# Altium schematic sheet D2A-Rev2b.SchDoc
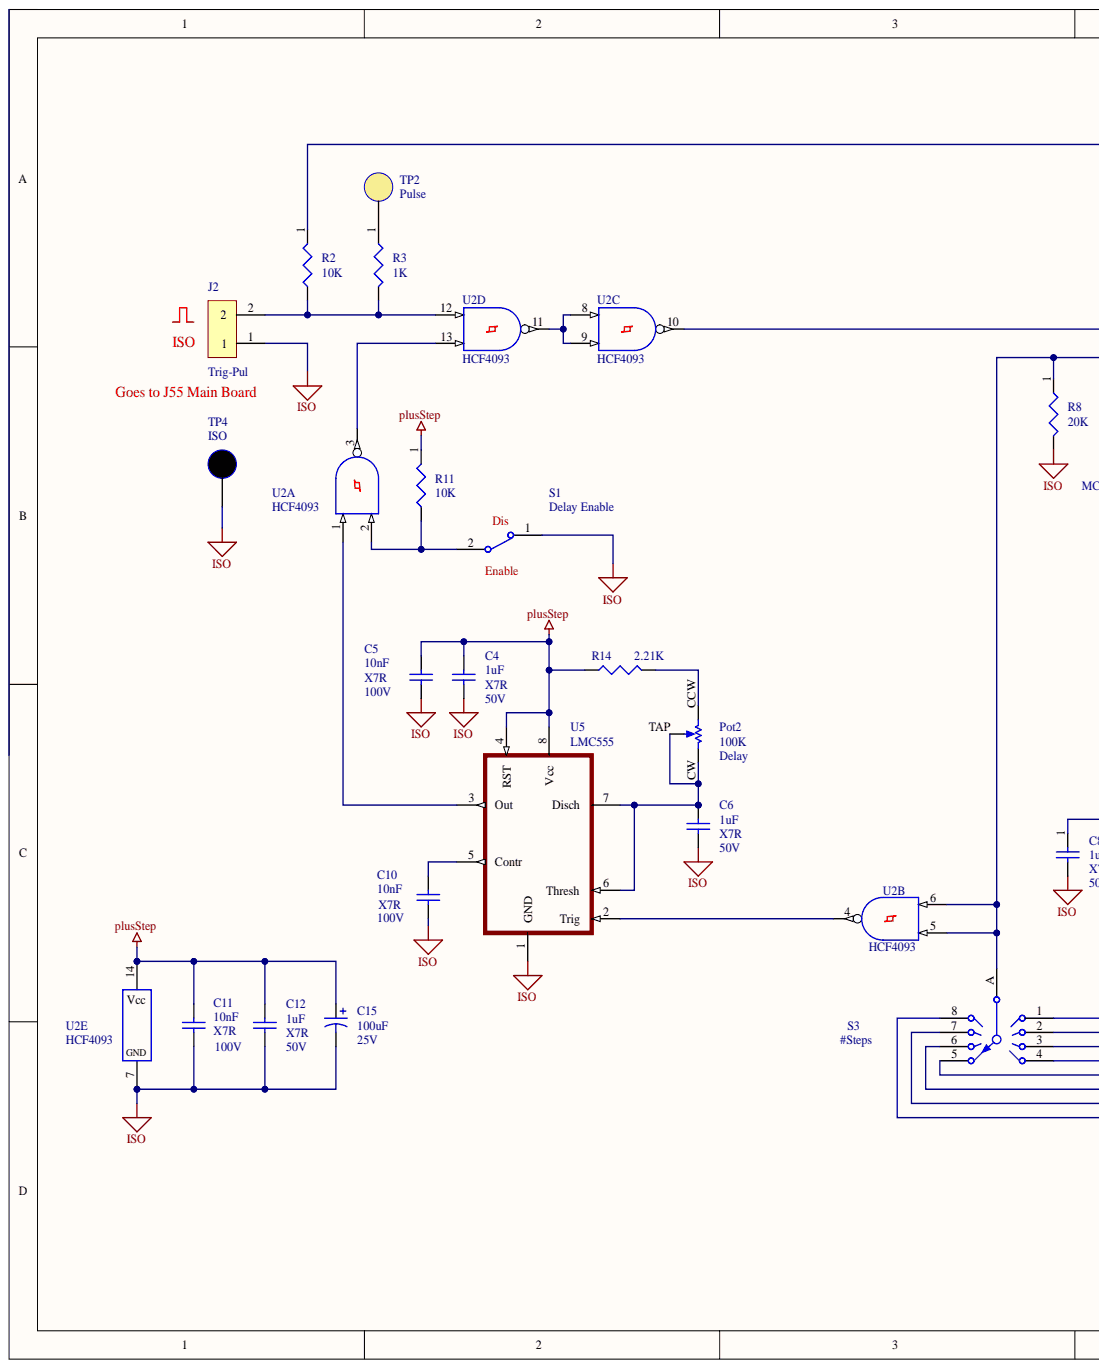
[diagram p0: D2A-Rev2b.SchDoc, left]
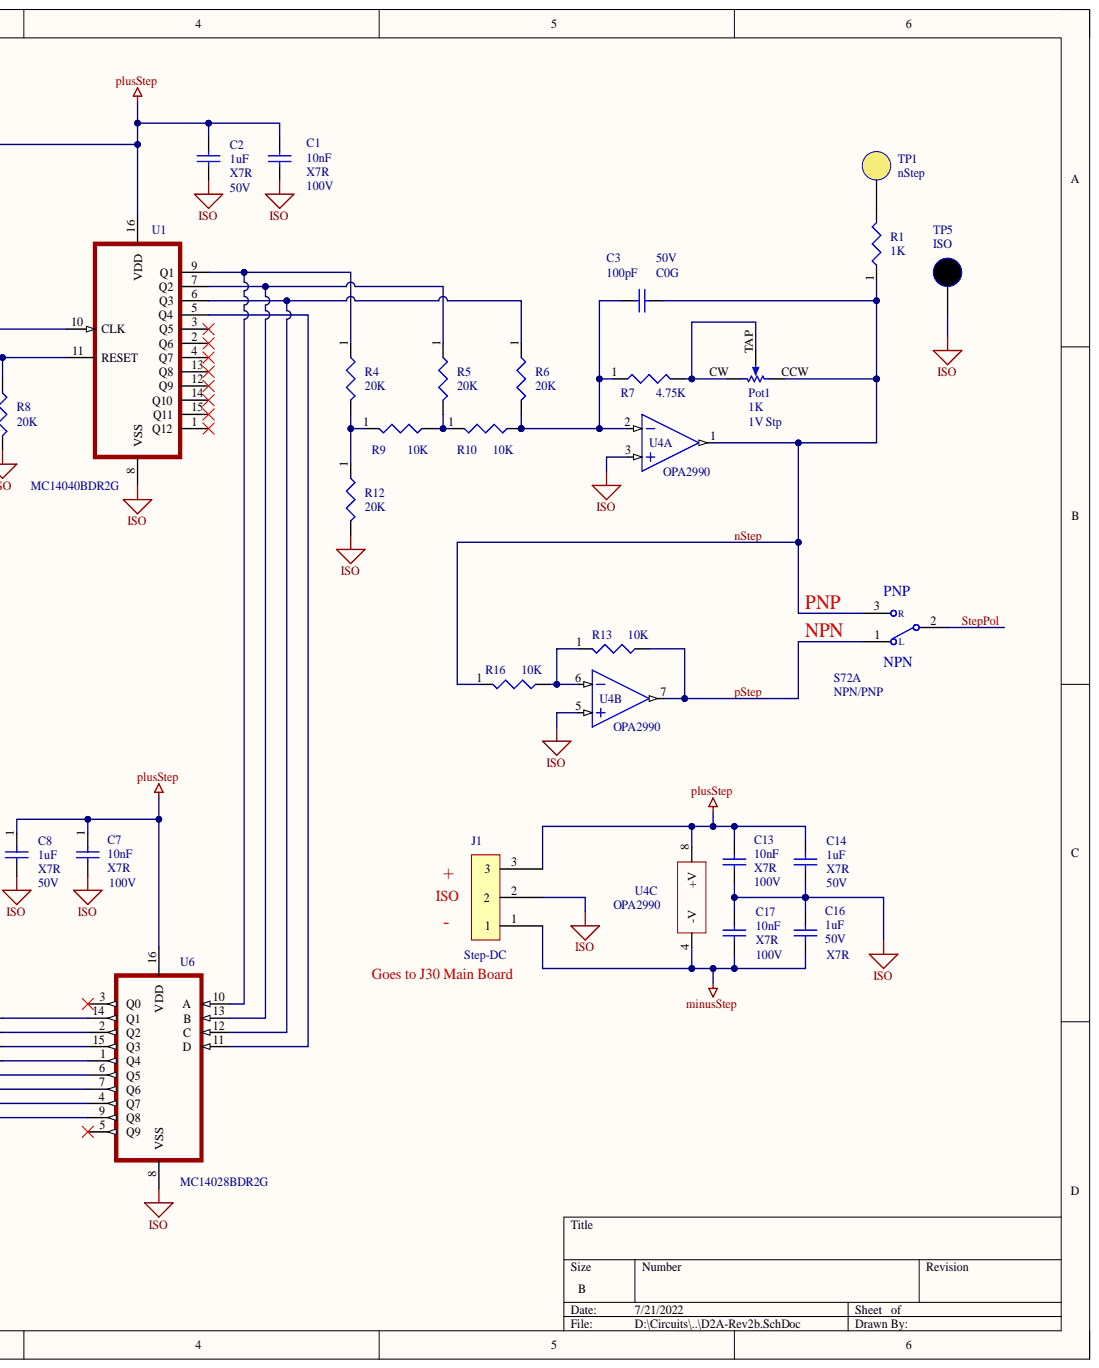
[diagram p1: D2A-Rev2b.SchDoc, right]

## Parts
C1  10nF  Dielectric: X7R  Voltage: 100V  [CAPC2012M]
C2  1uF  Dielectric: X7R  Voltage: 50V  [CAPC2012M]
C3  100pF  Dielectric: C0G  Voltage: 50V  [CAPC2012M]
C4  1uF  Dielectric: X7R  Voltage: 50V  [CAPC2012M]
C5  10nF  Dielectric: X7R  Voltage: 100V  [CAPC2012M]
C6  1uF  Dielectric: X7R  Voltage: 50V  [CAPC2012M]
C7  10nF  Dielectric: X7R  Voltage: 100V  [CAPC2012M]
C8  1uF  Dielectric: X7R  Voltage: 50V  [CAPC2012M]
C10  10nF  Dielectric: X7R  Voltage: 100V  [CAPC2012M]
C11  10nF  Dielectric: X7R  Voltage: 100V  [CAPC2012M]
C12  1uF  Dielectric: X7R  Voltage: 50V  [CAPC2012M]
C13  10nF  Dielectric: X7R  Voltage: 100V  [CAPC2012M]
C14  1uF  Dielectric: X7R  Voltage: 50V  [CAPC2012M]
C15  100uF  Voltage: 25V  [CAPPR2-5x11]
C16  1uF  Dielectric: X7R  Voltage: 50V  [CAPC2012M]
C17  10nF  Dielectric: X7R  Voltage: 100V  [CAPC2012M]
J1  Step-DC  [DTA-100-3]
J2  Trig-Pul  [DTA-100-2]
Pot1  1V Stp  value 1K  [7mmSq-CCW]
Pot2  Delay  value 100K  [Off-PCB-CCW]
R1  1K  [RESC2012M]
R2  10K  [RESC2012M]
R3  1K  [RESC2012M]
R4  20K  [RESC2012M]
R5  20K  [RESC2012M]
R6  20K  [RESC2012M]
R7  4.75K  [RESC2012M]
R8  20K  [RESC2012M]
R9  10K  [RESC2012M]
R10  10K  [RESC2012M]
R11  10K  [RESC2012M]
R12  20K  [RESC2012M]
R13  10K  [RESC2012M]
R14  2.21K  [RESC2012M]
R16  10K  [RESC2012M]
S1  Delay Enable  [2X1H-LS0.1-9mmHole]
S3  #Steps  [MRA112]
S72  NPN/PNP  [EG2425]  (part A)
TP1  nStep  [TP-.038]
TP2  Pulse  [TP-.038]
TP4  ISO  [TP-.038]
TP5  ISO  [TP-.038]
U1  MC14040BDR2G  [SOIC127P600X16-M]
U2  HCF4093  [SOIC127P600X14-M]  (parts A,B,C,D,E)
U4  OPA2990  [SOIC127P600X168_HS-8N]  (parts A,B,C)
U5  LMC555  [SOIC127P600X168_HS-8N]
U6  MC14028BDR2G  [SOIC127P600X16]

## Nets
ISO: C1.2 C2.2 C4.2 C5.2 C6.2 C7.2 C8.2 C10.2 C11.2 C12.2 C13.2 C14.2 C15.2 C16.2 C17.2 J1.2 J2.1 R8.2 R12.2 S1.1 TP4.1 TP5.1 U1.8(VSS) U2.7 U4.3 U4.5 U5.1(GND) U6.8(VSS)
minusStep: C16.1 C17.1 J1.1 U4.4(-V)
plusStep: C1.1 C2.1 C4.1 C5.1 C7.1 C8.1 C11.1 C12.1 C13.1 C14.1 C15.1 J1.3 R2.1 R11.1 R14.1 U1.16(VDD) U2.14 U4.8(+V) U5.4(RST) U5.8(Vcc) U6.16(VDD)
nStep: C3.2 Pot1.CCW R1.1 R16.1 S72.3(R) U4.1
pStep: R13.2 S72.1(L) U4.7
StepPol: S72.2
NetC3_1: C3.1 R6.2 R7.1 R10.2 U4.2
NetC6_1: C6.1 Pot2.CW Pot2.TAP U5.6(Thresh) U5.7(Disch)
NetC10_1: C10.1 U5.5(Contr)
NetJ2_2: J2.2 R2.2 R3.2 U2.12
NetPot1_CW: Pot1.CW Pot1.TAP R7.2
NetPot2_CCW: Pot2.CCW R14.2
NetR1_2: R1.2 TP1.1
NetR3_1: R3.1 TP2.1
NetR4_1: R4.1 U1.9(Q1) U6.10(A)
NetR4_2: R4.2 R9.1 R12.1
NetR5_1: R5.1 U1.7(Q2) U6.13(B)
NetR5_2: R5.2 R9.2 R10.1
NetR6_1: R6.1 U1.6(Q3) U6.12(C)
NetR8_1: R8.1 S3.A U1.11(RESET) U2.5 U2.6
NetR11_2: R11.2 S1.2 U2.2
NetR13_1: R13.1 R16.2 U4.6
NetS3_1: S3.1 U6.14(Q1)
NetS3_2: S3.2 U6.2(Q2)
NetS3_3: S3.3 U6.15(Q3)
NetS3_4: S3.4 U6.1(Q4)
NetS3_5: S3.5 U6.6(Q5)
NetS3_6: S3.6 U6.7(Q6)
NetS3_7: S3.7 U6.4(Q7)
NetS3_8: S3.8 U6.9(Q8)
NetU1_5: U1.5(Q4) U6.11(D)
NetU1_10: U1.10(CLK) U2.10
NetU2_1: U2.1 U5.3(Out)
NetU2_3: U2.3 U2.13
NetU2_4: U2.4 U5.2(Trig)
NetU2_8: U2.8 U2.9 U2.11

Not connected: U1 1(Q12) 2(Q6) 3(Q5) 4(Q7) 12(Q9) 13(Q8) 14(Q10) 15(Q11); U6 3(Q0) 5(Q9)

## Library data of the parts
C1 C5 C7 C10 C11 C13 C17 = Cap: CAP CER 10NF 100V X7R 0805; Manufacturer: Samsung; Manufacturer Part Number: CL21B103KCANNNC; Dielectric Material: Ceramic; Dissipation Factor: 2.5%; Tolerance: 10%; Capacitance: 10nF; Case Code (Imperial): 0805; Case Code (Metric): 2012; Case/Package: 0805; Component Kind: Standard; Construction: Flat; Contact Plating: Tin; Depth: 1.25mm; Height: 0.65mm; Length: 2mm; Library Name: Miscellaneous Devices.IntLib; Material: Ceramic; Max Operating Temperature: 125°C; Min Operating Temperature: -55°C; Mount: Surface Mount; Number of Pins: 2; Package Quantity: 1; Packaging: Tape and Reel; Radiation Hardening: No; REACH SVHC: No SVHC; RoHS Compliant: Yes; Series: CL; Signal Integrity: Cap; Simulation: CAP; Supplier: Digi-Key; Supplier Part Number: 1276-1249-6-ND; Termination: SMD/SMT; Thickness: 0.03inch; Value: 10nF; Voltage Rating: 100V; Voltage Rating (DC): 100V; Width: 0.049inch
C2 C4 C6 C8 C12 C14 C16 = Cap: CAP CER 1UF 50V X7R 0805; Manufacturer: Samsung; Manufacturer Part Number: CL21B105KBFNNNE; Dielectric Material: Ceramic; Tolerance: 10%; Capacitance: 1uF; Case Code (Imperial): 0805; Case Code (Metric): 2012; Case/Package: 0805; Component Kind: Standard; Construction: Flat; Depth: 1.25mm; Height: 1.25mm; Length: 2mm; Library Name: Miscellaneous Devices.IntLib; Max Operating Temperature: 125°C; Min Operating Temperature: -55°C; Mount: Surface Mount; Number of Pins: 2; Package Quantity: 1; Packaging: Tape and Reel; Radiation Hardening: No; REACH SVHC: No SVHC; RoHS Compliant: Yes; Series: CL; Signal Integrity: Cap; Simulation: CAP; Supplier: Digi-Key; Supplier Part Number: 1276-1029-6-ND; Termination: SMD/SMT; Thickness: 0.053inch; Value: 1uF; Voltage Rating: 50V; Voltage Rating (DC): 50V; Width: 0.049inch
C3 = Cap: CAP CER 100PF 50V COG 0805; Manufacturer: KEMET; Manufacturer Part Number: C0805C101J5GACTU; Dielectric Material: Ceramic; Dissipation Factor: 0.1%; Temperature Coefficient: 30ppm/°C; Tolerance: 5%; Capacitance: 100pF; Case Code (Imperial): 0805; Case Code (Metric): 2012; Case/Package: 0805; Component Kind: Standard; Construction: Flat; Contact Material: Tin; Contact Plating: Tin,Matte; Depth: 1.25mm; Height: 0.78mm; Lead Free: Lead Free; Lead Pitch: 0.75mm; Length: 2mm; Library Name: Miscellaneous Devices.IntLib; Max Operating Temperature: 125°C; Min Operating Temperature: -55°C; Mount: Surface Mount,PCB; Number of Pins: 2; Package Quantity: 4000; Packaging: Tape and Reel; Radiation Hardening: No; REACH SVHC: No SVHC; RoHS Compliant: Yes; Series: C; Signal Integrity: Cap; Simulation: CAP; Supplier: Digi-Key; Supplier Part Number: 399-1122-6-ND; Termination: SMD/SMT; Thickness: 0.035inch; Value: 100pF; Voltage Rating: 50V; Voltage Rating (DC): 50V; Width: 1.2mm
C15 = Cap Pol1: CAP ALUM 100uF 20% 25V; Manufacturer: Rubycon; Manufacturer Part Number: 25YXJ100M5X11; Impedance: 400mR; Lead Diameter: 0.5mm; Leakage Current: 3uA; Life (Hours): 5000Hour; Polarity: Polar; Ripple Current: 250mA; Capacitance: 100uF; Case/Package: Radial; Category: Aluminum Electrolytic Capacitors; Component Kind: Standard; ComponentLink1Description: https://datasheet.ciiva.com/11777/0900766b810307a1-11777001.pdf?src-supplier=RSComponents; ComponentLink1URL: https://datasheet.ciiva.com/11777/0900766b810307a1-11777001.pdf?src-supplier=RSComponents; ComponentLink2Description: https://datasheet.ciiva.com/41806/e-yxj-41806041.pdf?src-supplier=Digi-Key; ComponentLink2URL: https://datasheet.ciiva.com/41806/e-yxj-41806041.pdf?src-supplier=Digi-Key; ComponentLink3Description: https://datasheet.ciiva.com/11690/e-yxj-11690904.pdf?src-supplier=Avnet; ComponentLink3URL: https://datasheet.ciiva.com/11690/e-yxj-11690904.pdf?src-supplier=Avnet; Construction: RADIAL; Diameter: 5mm; Height: 11mm; Height - Seated (Max): 0.492inch; Lead Pitch: 2mm; Library Name: Miscellaneous Devices.IntLib; Max Operating Temperature: 105°C; Min Operating Temperature: -40°C; Mount: Through Hole; Package Quantity: 3000; Packaging: Bulk; Pricing 1: 1=0.25000, 10=0.17200, 50=0.10360, 100=0.08740, 500=0.05980, 1000=0.05290, 2500=0.05060, 5000=0.04600 (USD); Radiation Hardening: No; RoHS: Yes; RoHS Compliant: Yes; Series: YXJ; Signal Integrity: Cap Pol; Simulation: CAP; Stock 1: 7789; Supplier: Digi-Key; Supplier Part Number 1: 1189-2237-ND; Termination: Radial; Tolerance: 20%; Value: 100uF; Voltage Rating: 25V
J1 = 3-Pin: 2.54mm 3 pin; Manufacturer: TE Connectivity; Manufacturer Part Number: 640456-3; Approvals: CSA; Backwall/Post Interruptions: No (Without); Circuit Application: Signal; Connector System: Wire-to-Board; Connector Type: Connector,Pin,Plug,Header; Contact Current Rating: 5A; Contact Post Length: 3.56mm; Contact Resistance: 6mR; Contact Style: Straight; Gender: Male; Mating Connector Lock: Yes (With); Max Voltage Rating (AC): 250V; Number of Contacts: 3; PCB Mount Retention: Without; PCB Mounting Orientation: Vertical; PCB Thickness: 0.063inch; Plating Thickness: 3.81um; Tail Length: 0.14inch; Value: 640456-3; Category: Connectors; Color: Beige,White; Component Kind: Standard; ComponentLink1Description: http://www.farnell.com/datasheets/2043229.pdf?src-supplier=Farnell; ComponentLink1URL: http://www.farnell.com/datasheets/2043229.pdf?src-supplier=Farnell; ComponentLink2Description: http://www.farnell.com/datasheets/2043229.pdf?src-supplier=Element14; ComponentLink2URL: http://www.farnell.com/datasheets/2043229.pdf?src-supplier=Element14; ComponentLink3Description: http://www.farnell.com/datasheets/2043229.pdf?src-supplier=Newark; ComponentLink3URL: http://www.farnell.com/datasheets/2043229.pdf?src-supplier=Newark; ComponentLink4Description: https://www.te.com/commerce/DocumentDelivery/DDEController?Action=srchrtrv&DocNm=640456&DocType=Customer+Drawing&DocLang=English&src-supplier=Digi-Key; ComponentLink4URL: https://www.te.com/commerce/DocumentDelivery/DDEController?Action=srchrtrv&DocNm=640456&DocType=Customer+Drawing&DocLang=English&src-supplier=Digi-Key; ComponentLink5Description: https://datasheet.ciiva.com/11746/0900766b80027008-11746172.pdf?src-supplier=RSComponents; ComponentLink5URL: https://datasheet.ciiva.com/11746/0900766b80027008-11746172.pdf?src-supplier=RSComponents; ComponentLink6Description: https://datasheet.ciiva.com/6005/1712135-6005440.pdf?src-supplier=Farnell; ComponentLink6URL: https://datasheet.ciiva.com/6005/1712135-6005440.pdf?src-supplier=Farnell; ComponentLink7Description: https://datasheet.ciiva.com/19474/613940-19474164.pdf?src-supplier=Jameco; ComponentLink7URL: https://datasheet.ciiva.com/19474/613940-19474164.pdf?src-supplier=Jameco; ComponentLink8Description: https://datasheet.ciiva.com/16456/eng-ds-82056-mta-0608-16456886.pdf?src-supplier=Future+Electronics; ComponentLink8URL: https://datasheet.ciiva.com/16456/eng-ds-82056-mta-0608-16456886.pdf?src-supplier=Future+Electronics; ComponentLink9Description: https://datasheet.ciiva.com/14156/04384301-14156821.pdf?src-supplier=Distrelec; ComponentLink9URL: https://datasheet.ciiva.com/14156/04384301-14156821.pdf?src-supplier=Distrelec; ComponentLink10Description: https://datasheet.ciiva.com/16656/eng-ds-82056-mta-0608-16656523.pdf?src-supplier=Avnet+Europe; ComponentLink10URL: https://datasheet.ciiva.com/16656/eng-ds-82056-mta-0608-16656523.pdf?src-supplier=Avnet+Europe; ComponentLink11Description: https://datasheet.ciiva.com/30127/8852eng-ds-82056-mta-0608-30127480.pdf?src-supplier=Onlinecomponents.com; ComponentLink11URL: https://datasheet.ciiva.com/30127/8852eng-ds-82056-mta-0608-30127480.pdf?src-supplier=Onlinecomponents.com; ComponentLink12Description: https://datasheet.ciiva.com/14637/70083643-14637750.pdf?src-supplier=Allied+Electronics; ComponentLink12URL: https://datasheet.ciiva.com/14637/70083643-14637750.pdf?src-supplier=Allied+Electronics; ComponentLink13Description: https://datasheet.ciiva.com/7128/eng-ds-82056-mta-0608-7128744.pdf?src-supplier=Newark; ComponentLink13URL: https://datasheet.ciiva.com/7128/eng-ds-82056-mta-0608-7128744.pdf?src-supplier=Newark; ComponentLink14Description: https://datasheet.ciiva.com/709/eng-cd-640456-w2-709688.pdf?src-supplier=Digi-Key; ComponentLink14URL: https://datasheet.ciiva.com/709/eng-cd-640456-w2-709688.pdf?src-supplier=Digi-Key; ComponentLink15Description: https://datasheet.ciiva.com/16047/1984976-16047357.pdf?src-supplier=Onlinecomponents.com; ComponentLink15URL: https://datasheet.ciiva.com/16047/1984976-16047357.pdf?src-supplier=Onlinecomponents.com; ComponentLink16Description: https://datasheet.ciiva.com/11758/0900766b811d406d-11758596.pdf?src-supplier=RSComponents; ComponentLink16URL: https://datasheet.ciiva.com/11758/0900766b811d406d-11758596.pdf?src-supplier=RSComponents; ComponentLink17Description: https://datasheet.ciiva.com/26840/getdatasheetpartid-743835-26840596.pdf?src-supplier=Verical; ComponentLink17URL: https://datasheet.ciiva.com/26840/getdatasheetpartid-743835-26840596.pdf?src-supplier=Verical; ComponentLink18Description: https://datasheet.ciiva.com/6752/combiconspring-cagepcbterm-blocks-6752927.pdf?src-supplier=Digi-Key; ComponentLink18URL: https://datasheet.ciiva.com/6752/combiconspring-cagepcbterm-blocks-6752927.pdf?src-supplier=Digi-Key; ComponentLink19Description: https://datasheet.ciiva.com/16051/1988969-16051361.pdf?src-supplier=Onlinecomponents.com; ComponentLink19URL: https://datasheet.ciiva.com/16051/1988969-16051361.pdf?src-supplier=Onlinecomponents.com; ComponentLink20Description: https://datasheet.ciiva.com/823/combiconcompactseries-823768.pdf?src-supplier=Digi-Key; ComponentLink20URL: https://datasheet.ciiva.com/823/combiconcompactseries-823768.pdf?src-supplier=Digi-Key; ComponentLink21Description: https://datasheet.ciiva.com/14609/70055172-14609515.pdf?src-supplier=Allied+Electronics; ComponentLink21URL: https://datasheet.ciiva.com/14609/70055172-14609515.pdf?src-supplier=Allied+Electronics; Contact Finish - Mating: TIN; Contact Gender: Male; Contact Material: Copper Alloy; Contact Mating Length: 7.49mm; Contact Plating: Tin; Contact Retention: No (Without); Contact Shape: Square; Contact Type: Male Pin; Current Rating: 5A; Depth: 5.72mm; ELV: Compliant; Fastening Type: Friction; Flammability Rating: UL94 V-0; Height: 7.87mm; Housing Color: Natural; Housing Material: Polyester; Insulation Color: Natural; Insulation Material: Thermoplastic; Lead Free: Lead Free; Length: 0.4inch; Library Name: MY_CONNECTORS.SchLib; Mating Alignment: No (Without); Mating Retention: No (Without); Max Operating Temperature: 221°C; Min Operating Temperature: -67°C; Mount: Through Hole; Mounting Ears: No (Without); Number of Positions: 3; Number of Rows: 1; Orientation: Straight; Package Quantity: 5000; Packaging: Bulk; Panel Mount Retention: No (Without); PCB Mount Alignment: No (Without); Pitch: 2.54mm; Pricing 1: 1=0.16000, 10=0.13500, 100=0.10360, 500=0.08766, 1000=0.07173, 5000=0.06376, 10000=0.05977 (USD); Radiation Hardening: No; REACH SVHC: Unknown; RoHS: Yes; RoHS Compliant: Yes; Sealable: No (Without); Series: MTA-100; Shrouded: No (Without); Stock 1: 96416; Strain Relief: No (Without); Supplier: Digi-Key; Supplier Part Number: A19470-ND; Termination: Solder; Voltage Rating: 250V; Voltage Rating (AC): 250V
J2 = 2-Pin: 2.54mm 2pin; Manufacturer: TE Connectivity; Manufacturer Part Number: 640456-2; Approvals: CSA; Backwall/Post Interruptions: No (Without); Circuit Application: Signal; Connector System: Wire-to-Board; Connector Type: Connector,Pin,Plug,Header; Contact Current Rating: 5A; Contact Post Length: 3.56mm; Contact Resistance: 6mR; Contact Style: Straight; Gender: Male; Mating Connector Lock: Yes (With); Max Voltage Rating (AC): 250V; Number of Contacts: 2; PCB Mount Retention: Without; PCB Mounting Orientation: Vertical; PCB Thickness: 0.063inch; Plating Thickness: 3.81um; Tail Length: 0.14inch; Value: 640456-2; Category: Headers and Wire Housings; Color: Natural,White,Beige; Component Kind: Standard; ComponentLink1Description: https://datasheet.ciiva.com/709/eng-cd-640456-w1-709680.pdf?src-supplier=Digi-Key; ComponentLink1URL: https://datasheet.ciiva.com/709/eng-cd-640456-w1-709680.pdf?src-supplier=Digi-Key; ComponentLink2Description: https://datasheet.ciiva.com/3118/eng-ds-82056-mta-0608-3118497.pdf?src-supplier=Avnet; ComponentLink2URL: https://datasheet.ciiva.com/3118/eng-ds-82056-mta-0608-3118497.pdf?src-supplier=Avnet; ComponentLink3Description: https://datasheet.ciiva.com/16401/eng-ds-82056-mta-0608-16401289.pdf?src-supplier=Future+Electronics; ComponentLink3URL: https://datasheet.ciiva.com/16401/eng-ds-82056-mta-0608-16401289.pdf?src-supplier=Future+Electronics; ComponentLink4Description: https://datasheet.ciiva.com/25617/0900766b80027008-25617244.pdf?src-supplier=RSComponents; ComponentLink4URL: https://datasheet.ciiva.com/25617/0900766b80027008-25617244.pdf?src-supplier=RSComponents; ComponentLink5Description: https://datasheet.ciiva.com/14156/04384301-14156820.pdf?src-supplier=Distrelec; ComponentLink5URL: https://datasheet.ciiva.com/14156/04384301-14156820.pdf?src-supplier=Distrelec; ComponentLink6Description: https://datasheet.ciiva.com/11746/0900766b80eb7fdd-11746365.pdf?src-supplier=RSComponents; ComponentLink6URL: https://datasheet.ciiva.com/11746/0900766b80eb7fdd-11746365.pdf?src-supplier=RSComponents; ComponentLink7Description: https://datasheet.ciiva.com/7128/1866390-7128742.pdf?src-supplier=Newark; ComponentLink7URL: https://datasheet.ciiva.com/7128/1866390-7128742.pdf?src-supplier=Newark; ComponentLink8Description: https://datasheet.ciiva.com/16505/eng-ds-82056-mta-0608-16505419.pdf?src-supplier=Avnet+Asia; ComponentLink8URL: https://datasheet.ciiva.com/16505/eng-ds-82056-mta-0608-16505419.pdf?src-supplier=Avnet+Asia; ComponentLink9Description: https://datasheet.ciiva.com/18620/1713017-18620870.pdf?src-supplier=Digi-Key; ComponentLink9URL: https://datasheet.ciiva.com/18620/1713017-18620870.pdf?src-supplier=Digi-Key; ComponentLink10Description: https://datasheet.ciiva.com/16047/1989748-16047438.pdf?src-supplier=Onlinecomponents.com; ComponentLink10URL: https://datasheet.ciiva.com/16047/1989748-16047438.pdf?src-supplier=Onlinecomponents.com; ComponentLink11Description: https://datasheet.ciiva.com/11758/0900766b811d404e-11758587.pdf?src-supplier=RSComponents; ComponentLink11URL: https://datasheet.ciiva.com/11758/0900766b811d404e-11758587.pdf?src-supplier=RSComponents; ComponentLink12Description: https://datasheet.ciiva.com/6746/combiconspring-cagepcbterm-blocks-6746175.pdf?src-supplier=Digi-Key; ComponentLink12URL: https://datasheet.ciiva.com/6746/combiconspring-cagepcbterm-blocks-6746175.pdf?src-supplier=Digi-Key; ComponentLink13Description: https://datasheet.ciiva.com/14607/70055059-14607702.pdf?src-supplier=Allied+Electronics; ComponentLink13URL: https://datasheet.ciiva.com/14607/70055059-14607702.pdf?src-supplier=Allied+Electronics; ComponentLink14Description: https://datasheet.ciiva.com/16051/1988956-16051359.pdf?src-supplier=Onlinecomponents.com; ComponentLink14URL: https://datasheet.ciiva.com/16051/1988956-16051359.pdf?src-supplier=Onlinecomponents.com; ComponentLink15Description: https://datasheet.ciiva.com/26340/567541-da-01-de-printklemme-1988956-250-st-26340451.pdf?src-supplier=Conrad; ComponentLink15URL: https://datasheet.ciiva.com/26340/567541-da-01-de-printklemme-1988956-250-st-26340451.pdf?src-supplier=Conrad; ComponentLink16Description: https://datasheet.ciiva.com/824/combiconcompactseries-824224.pdf?src-supplier=Digi-Key; ComponentLink16URL: https://datasheet.ciiva.com/824/combiconcompactseries-824224.pdf?src-supplier=Digi-Key; ComponentLink17Description: https://datasheet.ciiva.com/14609/70055171-14609100.pdf?src-supplier=Allied+Electronics; ComponentLink17URL: https://datasheet.ciiva.com/14609/70055171-14609100.pdf?src-supplier=Allied+Electronics; Contact Gender: Male; Contact Material: Copper Alloy; Contact Mating Length: 7.49mm; Contact Plating: Tin; Contact Retention: No (Without); Contact Shape: Square; Contact Type: Male Pin; Current Rating: 5A; Depth: 5.72mm; ELV: Compliant; Fastening Type: Friction; Flammability Rating: UL94 V-0; Height: 7.87mm; Housing Color: Natural; Housing Material: Polyester; Lead Free: Lead Free; Lead Pitch: 2.54mm; Length: 7.62mm; Library Name: MY_CONNECTORS.SchLib; Mating Alignment: No (Without); Mating Retention: No (Without); Max Operating Temperature: 221°C; Min Operating Temperature: -67°C; Mount: Through Hole; Mounting Ears: No (Without); Number of Poles: 2; Number of Positions: 2; Number of Rows: 1; Orientation: Straight; Package Quantity: 5000; Packaging: Bulk; Panel Mount Retention: No (Without); PCB Mount Alignment: No (Without); Pitch: 2.54mm; Pricing 1: 1=0.13000, 10=0.10400, 100=0.07700, 500=0.06374, 1000=0.05578, 5000=0.04781, 10000=0.04648 (USD); Radiation Hardening: No; REACH SVHC: No SVHC; RoHS: Yes; RoHS Compliant: Yes; Sealable: No (Without); Series: MTA-100; Shrouded: No (Without); Stock 1: 18986; Strain Relief: No (Without); Supplier: Digi-Key; Supplier Part Number: A1921-ND; Termination: Solder; Voltage Rating: 250V; Voltage Rating (AC): 250V; Working Voltage: 250V
Pot1 = POT-Single: TRIMMER 1K OHM 0.5W TH; Manufacturer: Nidec Copal; Manufacturer Part Number: CT6EP102; Max Power Dissipation: 500mW; Number of Turns: 1; Resistance: 1kR; Temperature Coefficient: 100ppm/°C; Tolerance: 10%; Color: Blue; Component Kind: Standard; Contact Plating: Tin; Lead Free: Lead Free; Length: 0.228inch; Max Operating Temperature: 120°C; Min Operating Temperature: -55°C; Mount: Through Hole; Packaging: Bulk; Resistor Type: Cermet; Series: CT6; Supplier: Digi-Key; Supplier Part Number: CT6EP102-ND; Termination: PC Pins; Weight: 510mg; Library Name: MY_POTS.SchLib
Pot2 = POT-Single: Res Conductive Plastic POT 100K Ohm 20% 1/4W 1(Elec)/1(Mech)Turn 3.18mm (9.53 X 9.75 X 20.24mm) Pin Panel...; Manufacturer: Bourns; Manufacturer Part Number: 3306P-1-104; Actuator Diameter: 0.125inch; Actuator Length: 0.5inch; Hole Diameter: 6.35mm; Lead Diameter: 1mm; Linearity: 5%; Max Power Dissipation: 200mW; Number of Gangs: 2; Number of Turns: 1; Pitch: 2.5mm; Resistance: 100kR; Temperature Coefficient: 250ppm/°C; Tolerance: 25%; Voltage Rating: 100V; Category: Variable Resistors and Potentiometers; Color: Blue; Colour: Blue; Component Kind: Standard; ComponentLink1Description: https://datasheet.ciiva.com/11831/0900766b8069b9f0-11831916.pdf?src-supplier=RSComponents; ComponentLink1URL: https://datasheet.ciiva.com/11831/0900766b8069b9f0-11831916.pdf?src-supplier=RSComponents; ComponentLink2Description: https://datasheet.ciiva.com/2153/3310-2153448.pdf?src-supplier=Digi-Key; ComponentLink2URL: https://datasheet.ciiva.com/2153/3310-2153448.pdf?src-supplier=Digi-Key; ComponentLink3Description: https://datasheet.ciiva.com/16808/3310-16808375.pdf?src-supplier=Avnet+Europe; ComponentLink3URL: https://datasheet.ciiva.com/16808/3310-16808375.pdf?src-supplier=Avnet+Europe; ComponentLink4Description: https://datasheet.ciiva.com/1475/1653582-1475470.pdf?src-supplier=Element14; ComponentLink4URL: https://datasheet.ciiva.com/1475/1653582-1475470.pdf?src-supplier=Element14; Composition: Cermet; Depth: 8.1mm; Diameter: 6.81mm; Height: 7.62mm; Lead Free: Lead Free; Length: 6.81mm; Max Operating Temperature: 100°C; Military Standard: Not; Min Operating Temperature: -25°C; Mount: Through Hole,PCB; Number of Terminals: 3; Package Quantity: 200; Packaging: Bulk; Power Rating: 200mW; Pricing 1: 1=12.32000, 10=11.88000, 25=10.56000, 50=9.68000, 100=8.80000, 250=7.04000, 500=6.82000, 1000=6.38000 (USD); Radiation Hardening: No; REACH SVHC: No SVHC; Resistance Tolerance: 20%; Resistor Type: Conductive Plastic; RoHS: Yes; RoHS Compliant: Yes; Series: Pro Audio 3310; Stock 1: 46; Supplier: Digi-Key; Supplier Part Number: 3306P-104-ND; Taper: Linear; Technology: CONDUCTIVE PLASTIC; Termination: Pin; Type: Potentiometer; Voltage Rating (DC): 100V; Weight: 360mg; Width: 6.81mm; Library Name: MY_POTS.SchLib
R1 R3 = Res3: RES 1K OHM 1% 1/4W 0805; Manufacturer: KOA Speer; Manufacturer Part Number: RK73H2ATTD1001F; Max Power Dissipation: 250mW; Power Rating: 125mW; Resistance: 1kR; Temperature Coefficient: 100ppm/°C; Voltage Rating: 150V; Case Code (Imperial): 0805; Case Code (Metric): 2012; Case/Package: 0805; Component Kind: Standard; Composition: Thick Film; Construction: Rectangular; Contact Plating: Matte,Tin; Depth: 1.25mm; Features: Automotive AEC-Q200; Height: 0.5mm; Lead Free: Lead Free; Length: 2mm; Library Name: Miscellaneous Devices.IntLib; Max Operating Temperature: 155°C; Military Standard: Not; Min Operating Temperature: -55°C; Mount: Surface Mount; Number of Pins: 2; Number of Terminations: 2; Package Quantity: 5000; Packaging: Tape and Reel; Radiation Hardening: No; REACH SVHC: Unknown; Resistance Tolerance: 1%; Resistor Type: Thick Film; RoHS Compliant: Yes; Series: RK73H; Signal Integrity: Res; Simulation: RESISTOR; Supplier: Digi-Key; Supplier Part Number: 2019-RK73H2ATTD1001FCT-ND; Termination: SMD/SMT; Tolerance: 1%; Value: 1K; Voltage Rating (DC): 150V; Width: 1.25mm
R2 R9-R11 R13 R16 = Res3: RES 10K OHM 1% 1/4W 0805; Manufacturer: KOA Speer; Manufacturer Part Number: RK73H2ATTD1002F; Max Power Dissipation: 250mW; Power Rating: 125mW; Resistance: 10kR; Temperature Coefficient: 100ppm/°C; Voltage Rating: 150V; Case Code (Imperial): 0805; Case Code (Metric): 2012; Case/Package: 0805; Component Kind: Standard; Composition: Thick Film; Construction: Rectangular; Contact Plating: Matte,Tin; Depth: 1.25mm; Features: Automotive AEC-Q200; Height: 0.5mm; Lead Free: Lead Free; Length: 2mm; Library Name: Miscellaneous Devices.IntLib; Max Operating Temperature: 155°C; Military Standard: Not; Min Operating Temperature: -55°C; Mount: Surface Mount; Number of Pins: 2; Number of Terminations: 2; Package Quantity: 5000; Packaging: Tape and Reel; Radiation Hardening: No; REACH SVHC: Unknown; Resistance Tolerance: 1%; Resistor Type: Thick Film; Series: RK73H; Signal Integrity: Res; Simulation: RESISTOR; Supplier: Digi-Key; Supplier Part Number: 2019-RK73H2ATTD1002FCT-ND; Termination: SMD/SMT; Tolerance: 1%; Value: 10K; Voltage Rating (DC): 150V; Width: 1.25mm
R4-R6 R8 R12 = Res3: RES 20K OHM 1% 1/4W 0805; Manufacturer: KOA Speer; Manufacturer Part Number: RK73H2ATTD2002F; Power Rating: 125mW; Resistance: 20kR; Temperature Coefficient: 100ppm/°C; Voltage Rating: 150V; Case Code (Imperial): 0805; Case Code (Metric): 2012; Case/Package: 0805; Component Kind: Standard; Construction: Rectangular; Depth: 1.25mm; Height: 0.5mm; Lead Free: Lead Free; Length: 2mm; Library Name: Miscellaneous Devices.IntLib; Max Operating Temperature: 155°C; Military Standard: Not; Min Operating Temperature: -55°C; Package Quantity: 5000; Packaging: Tape and Reel; REACH SVHC: Unknown; Resistance Tolerance: 1%; Resistor Type: Thick Film; RoHS Compliant: Yes; Series: RK73H; Signal Integrity: Res; Simulation: RESISTOR; Supplier: Digi-Key; Supplier Part Number: 2019-RK73H2ATTD2002FCT-ND; Termination: SMD/SMT; Tolerance: 1%; Value: 20K; Voltage Rating (DC): 150V; Width: 1.25mm
R7 = Res3: RES 4.75K OHM 1% 1/4W 0805; Manufacturer: KOA Speer; Manufacturer Part Number: RK73H2ATTD4751F; Power Rating: 125mW; Resistance: 4.75kR; Temperature Coefficient: 100ppm/°C; Voltage Rating: 150V; Case Code (Imperial): 0805; Case Code (Metric): 2012; Case/Package: 0805; Component Kind: Standard; Height: 0.5mm; Lead Free: Lead Free; Length: 2mm; Library Name: Miscellaneous Devices.IntLib; Max Operating Temperature: 155°C; Min Operating Temperature: -55°C; Package Quantity: 5000; Packaging: Tape and Reel; RoHS Compliant: Yes; Series: RK73H; Signal Integrity: Res; Simulation: RESISTOR; Supplier: Digi-Key; Supplier Part Number: 2019-RK73H2ATTD4751FCT-ND; Termination: SMD/SMT; Tolerance: 1%; Value: 4.75K; Voltage Rating (DC): 150V; Width: 1.25mm
R14 = Res3: RES 2.21K OHM 1% 1/4W 0805; Manufacturer: KOA Speer; Manufacturer Part Number: RK73H2ATTD2211F; Max Power Dissipation: 250mW; Power Rating: 125mW; Resistance: 2.21kR; Temperature Coefficient: 100ppm/°C; Voltage Rating: 150V; Case Code (Imperial): 0805; Case Code (Metric): 2012; Case/Package: 0805; Category: Chip SMD Resistors; Component Kind: Standard; ComponentLink1Description: https://datasheet.ciiva.com/5557/rk73h-5557935.pdf?src-supplier=Mouser; ComponentLink1URL: https://datasheet.ciiva.com/5557/rk73h-5557935.pdf?src-supplier=Mouser; Composition: Thick Film; Construction: Rectangular; Contact Plating: Matte,Tin; Depth: 1.25mm; Features: Automotive AEC-Q200; Height: 0.5mm; Lead Free: Lead Free; Length: 2mm; Library Name: Miscellaneous Devices.IntLib; Max Operating Temperature: 155°C; Military Standard: Not; Min Operating Temperature: -55°C; Mount: Surface Mount; Number of Pins: 2; Number of Terminations: 2; Package Quantity: 5000; Packaging: Tape and Reel; Pricing 1: 1=0.10000, 10=0.04200, 100=0.01710, 1000=0.00768, 2500=0.00666 (USD); Radiation Hardening: No; REACH SVHC: Unknown; Resistance Tolerance: 1%; Resistor Type: Thick Film; RoHS: Yes; RoHS Compliant: Yes; Series: RK73H; Signal Integrity: Res; Simulation: RESISTOR; Supplier: Digi-Key; Supplier Part Number: 2019-RK73H2ATTD2211FCT-ND; Technology: THICK FILM; Termination: SMD/SMT; Tolerance: 1%; Value: 2.21K; Voltage Rating (DC): 150V; Width: 1.25mm
S1 = SPST: Res Conductive Plastic POT 100K Ohm 20% 1/4W 1(Elec)/1(Mech)Turn 3.18mm (9.53 X 9.75 X 20.24mm) Pin Panel...; Manufacturer: Bourns; Manufacturer Part Number: 3310H-003-104L; Actuator Diameter: 0.125inch; Actuator Length: 0.5inch; Hole Diameter: 6.35mm; Linearity: 5%; Max Power Dissipation: 250mW; Number of Gangs: 2; Number of Turns: 1; Resistance: 100kR; Temperature Coefficient: 1000ppm/°C; Voltage Rating: 200V; Category: Variable Resistors and Potentiometers; Component Kind: Standard; ComponentLink1Description: https://datasheet.ciiva.com/11831/0900766b8069b9f0-11831916.pdf?src-supplier=RSComponents; ComponentLink1URL: https://datasheet.ciiva.com/11831/0900766b8069b9f0-11831916.pdf?src-supplier=RSComponents; ComponentLink2Description: https://datasheet.ciiva.com/2153/3310-2153448.pdf?src-supplier=Digi-Key; ComponentLink2URL: https://datasheet.ciiva.com/2153/3310-2153448.pdf?src-supplier=Digi-Key; ComponentLink3Description: https://datasheet.ciiva.com/16808/3310-16808375.pdf?src-supplier=Avnet+Europe; ComponentLink3URL: https://datasheet.ciiva.com/16808/3310-16808375.pdf?src-supplier=Avnet+Europe; ComponentLink4Description: https://datasheet.ciiva.com/1475/1653582-1475470.pdf?src-supplier=Element14; ComponentLink4URL: https://datasheet.ciiva.com/1475/1653582-1475470.pdf?src-supplier=Element14; Depth: 9.75mm; Height: 9.53mm; Length: 9.53mm; Library Name: MY_SWITCH.SchLib; Max Operating Temperature: 125°C; Military Standard: Not; Min Operating Temperature: -40°C; Mount: Panel,Through Hole; Package Quantity: 50; Packaging: Bulk; Power Rating: 250mW; Pricing 1: 1=12.32000, 10=11.88000, 25=10.56000, 50=9.68000, 100=8.80000, 250=7.04000, 500=6.82000, 1000=6.38000 (USD); Radiation Hardening: No; REACH SVHC: No SVHC; Resistance Tolerance: 20%; Resistor Type: Conductive Plastic; RoHS: Yes; RoHS Compliant: Yes; Series: Pro Audio 3310; Stock 1: 46; Supplier: Digi-Key; Supplier Part Number: 3310H-003-104L-ND; Taper: Linear; Technology: CONDUCTIVE PLASTIC; Termination: Pin; Tolerance: 20%; Type: Potentiometer; Value: 100K; Voltage Rating (DC): 200V; Weight: 7g; Width: 9.53mm
S3 = 8Way-SW: Rotary Switch 125VAC 250mA 1-P 12-Pos 12 Power Tap Selection PC Terminal; Manufacturer: NKK Switches; Actuator Length: 10mm; Actuator Material: Brass; Illumination Type: Illuminated; Index Angle: 30°; Index Stops: Adjustable; Max Voltage Rating (AC): 125V; Number of Contacts: 1; Number of Positions: 12; Throw Configuration: SP12T,SP; Value: MRA112; Category: Rotary Switches; Component Kind: Standard; ComponentLink1Description: https://datasheet.ciiva.com/14540/70192036-14540681.pdf?src-supplier=Allied+Electronics; ComponentLink1URL: https://datasheet.ciiva.com/14540/70192036-14540681.pdf?src-supplier=Allied+Electronics; Contact Current Rating: 250mA; Contact Material: Copper,Brass,Silver; Contact Plating: Silver; Current Rating: 250mA; Depth: 16mm; Diameter: 16mm; Height: 32mm; Housing Material: Zinc; Length: 16mm; Library Name: MY_SWITCH.SchLib; Manufacturer Part Number: MRA112; Max Current Rating: 250mA; Max Operating Temperature: 70°C; Min Operating Temperature: -10°C; Mount: Panel,Through Hole; Number of Decks: 1; Number of Poles: 1; Number of Poles per Deck: 1; Package Quantity: 1; Pricing 1: 1=18.54000, 5=15.91800, 10=14.92100, 25=13.67360, 50=12.80100, 100=12.01130, 250=11.01380, 500=10.80600 (USD); REACH SVHC: No SVHC; RoHS: Yes; RoHS Compliant: Yes; Stock 1: 1681; Supplier: Digi-Key; Supplier Part Number: 360-2584-ND; Termination: PC Pins; Voltage Rating: 125V; Voltage Rating (AC): 125V
S72 = 3PDT: SWITCH TOGGLE 3PDT 5A 120V; Manufacturer: E-Switch; Manufacturer Part Number: 1003P1T1B1M2QEH; Actuator Length: 10.41mm; Actuator Material: Brass,Chrome; Actuator Type: Standard Round; Electrical Life: 40000Cycles; Illumination Type: Non-Illuminated,Illuminated; Switch Function: On-On; Value: EG2425; Voltage Rating (DC): 28V; Component Kind: Standard; Contact Material: Copper,Silver; Contact Plating: Silver; Current Rating: 5A; Lead Free: Lead Free; Length: 12.7mm; Library Name: MY_SWITCH.SchLib; Max Operating Temperature: 85°C; Min Operating Temperature: -30°C; Mount: Panel; Orientation: Vertical; Package Quantity: 1; Packaging: Bulk; Series: 100; Supplier: Digi-Key; Supplier Part Number: EG2425-ND; Termination: PC Pin; Throw Configuration: 3PDT; Voltage Rating (AC): 120V; Width: 16.5mm
TP1 TP2 = TP-Round-Yellow: TP Thru-Hole; Manufacturer: UW; Manufacturer Part Number: Gold; Component Kind: Standard; Library Name: MY_TP.SchLib; Supplier: UW; Value: TP Thru-Hole
  TP1: Supplier Part Num,ber: Gold
  TP2: Supplier Part Number: Gold
TP4 TP5 = TP-Round-Black: TP Thru-Hole; Manufacturer: UW; Manufacturer Part Number: Gold; Component Kind: Standard; Library Name: MY_TP.SchLib; Supplier: UW; Supplier Part Number: Gold; Value: TP Thru-Hole
U1 = CD4040BM96: IC COUNTER 12BIT CMOS 16SOIC; Manufacturer: ON Semiconductor; Built_By: EMA_UL_Team; Capacitance: 7.5pF; Clock Edge Trigger Type: Negative Edge; Direction: Up,Unidirectional; Frequency: 10MHz; High Level Output Current: -8.8mA; Low Level Output Current: 8.8mA; Max Supply Voltage: 18V; Min Supply Voltage: 3V; Number of Bits: 12; Number of Circuits: 1; Power Dissipation: 500mW; Propagation Delay: 3250ns; Quiescent Current: 20uA; Value: 4040; Vendor: Texas Instruments; Case/Package: SOIC; Component Kind: Standard; Contact Plating: Tin,Matte; Halogen Free: Halogen Free; Height: 1.5mm; Lead Free: Lead Free; Length: 10mm; Library Name: 4040.SchLib; Logic Function: Counter; Manufacturer Part Number: MC14040BDR2G; Max Operating Temperature: 125°C; Min Operating Temperature: -55°C; Mount: Surface Mount; Number of Bits per Element: 12; Number of Elements: 1; Number of Input Lines: 1; Number of Inputs: 1; Number of Output Lines: 12; Number of Pins: 16; Package Quantity: 2500; Packaging: Tape and Reel; Radiation Hardening: No; REACH SVHC: No SVHC; RefDes: RefDes; Supplier: Digi-Key; Supplier Part Number: MC14040BDR2GOSCT-ND; Turn-On Delay Time: 3250ns; Type: DEV; Width: 4mm; Copyright: Copyright (C) 2018 Accelerated Designs. All rights reserved
U2 = CD4093: Quad NAND w/Schmitt trigger; Manufacturer: STMicroelectronics; Manufacturer Part Number: HCF4093YM013TR; High Level Output Current: 6.8mA; Logic Function: NAND GATE; Max Power Dissipation: 200mW; Max Supply Voltage: 20V; Min Supply Voltage: 3V; Number of Circuits: 4; Number of Input Lines: 2; Number of Outputs: 1; Propagation Delay: 130ns; Quiescent Current: 20uA; Case/Package: SOIC; Component Kind: Standard; Lead Free: Lead Free; Library Name: MY_Logic Gate.SchLib; Low Level Output Current: 6.8mA; Max Operating Temperature: 125°C; Min Operating Temperature: -40°C; Mount: Surface Mount; Number of Elements: 4; Number of Gates: 4; Number of Inputs: 2; Number of Output Lines: 2; Number of Pins: 14; Package Quantity: 2500; Packaging: Tape and Reel; RoHS Compliant: Yes; Supplier: Digi-Key; Supplier Part Number: 497-14376-6-ND; Value: HCF4093; Weight: 0.009143oz
U4 = Dual OP Amp separte supplies: 40-V, dual 1MHz, rail-to-rail input/output, low-offset-voltage, low-power op amp 8-SOIC -40 to 125; Manufacturer: Texas Instruments; Manufacturer Part Number: OPA2990IDR; Category: Amplifiers - Op Amps, Buffer, Instrumentation; Component Kind: Standard; ComponentLink1Description: http://www.ti.com/general/docs/suppproductinfo.tsp?distId=10&amp;gotoUrl=http://www.ti.com/lit/gpn/OPA2990&src-supplier=Digi-Key; ComponentLink1URL: http://www.ti.com/general/docs/suppproductinfo.tsp?distId=10&amp;gotoUrl=http://www.ti.com/lit/gpn/OPA2990&src-supplier=Digi-Key; Library Name: My_OpAmps.SchLib; Pricing 1: 1=1.59000, 10=1.42700, 25=1.34640, 100=1.14700, 250=1.07696, 500=0.94234, 1000=0.88900 (USD); RoHS: Yes; Stock 1: 3095; Supplier: Digi-Key; Supplier Part Number: 296-53506-1-ND; Value: OPA2990
U5 = LM555: IC OSC SINGLE TIMER 3MHZ 8-SOIC; Manufacturer: Texas Instruments; Manufacturer Part Number: LMC555CMX/NOPB; Current - Supply: 150µA; Voltage - Supply: 1.5 V ~ 15 V; Category: Integrated Circuits (ICs); Component Kind: Standard; Frequency: 3MHz; Library Name: MY_National.SchLib; Mounting Type: Surface Mount; Operating Temperature: -40°C ~ 85°C; Package / Case: 8-SOIC (0.154", 3.90mm Width); Packaging: Cut Tape (CT); Part Status: Active; RoHS: RoHS Compliant; Supplier: Digi-Key; Supplier Device Package: 8-SOIC; Supplier Part Number: LMC555CMX/NOPBCT-ND; Type: 555 Type, Timer/Oscillator (Single); Value: LMC555
U6 = CD4028BM96: Demultiplexer 4-to-10 16-Pin SOIC; Manufacturer: ON Semiconductor; Built_By: EMA_UL_Team; High Level Output Current: -4.2mA; Independent Circuits: 1; Logic Function: Decoder,Demux,Demultiplexer; Low Level Output Current: 4.2mA; Max Supply Voltage: 18V; Min Supply Voltage: 3V; Number of Bits: 3; Number of Input Lines: 4; Number of Output Lines: 10; Polarity: Non-Inverting; Propagation Delay: 600ns; Quiescent Current: 20uA; Supply Type: Single,Dual; Value: 4028; Vendor: Texas Instruments; Case/Package: SOIC; Component Kind: Standard; Halogen Free: Halogen Free; Height: 1.5mm; Lead Free: Lead Free; Length: 10mm; Library Name: MY_4028.SchLib; Manufacturer Part Number: MC14028BDR2G; Max Operating Temperature: 125°C; Min Operating Temperature: -55°C; Mount: Surface Mount; Number of Circuits: 3; Number of Elements: 1; Number of Inputs: 4; Number of Outputs: 10; Number of Pins: 16; Package Quantity: 2500; Packaging: Tape and Reel; Radiation Hardening: No; REACH SVHC: No SVHC; RefDes: RefDes; Supplier: Digi-Key; Supplier Part Number: MC14028BDR2GOSCT-ND; Turn-On Delay Time: 600ns; Type: DEV; Width: 4mm; Copyright: Copyright (C) 2018 Accelerated Designs. All rights reserved
